# Altium schematic sheet JZ2440_sensors_v1.2.SchDoc
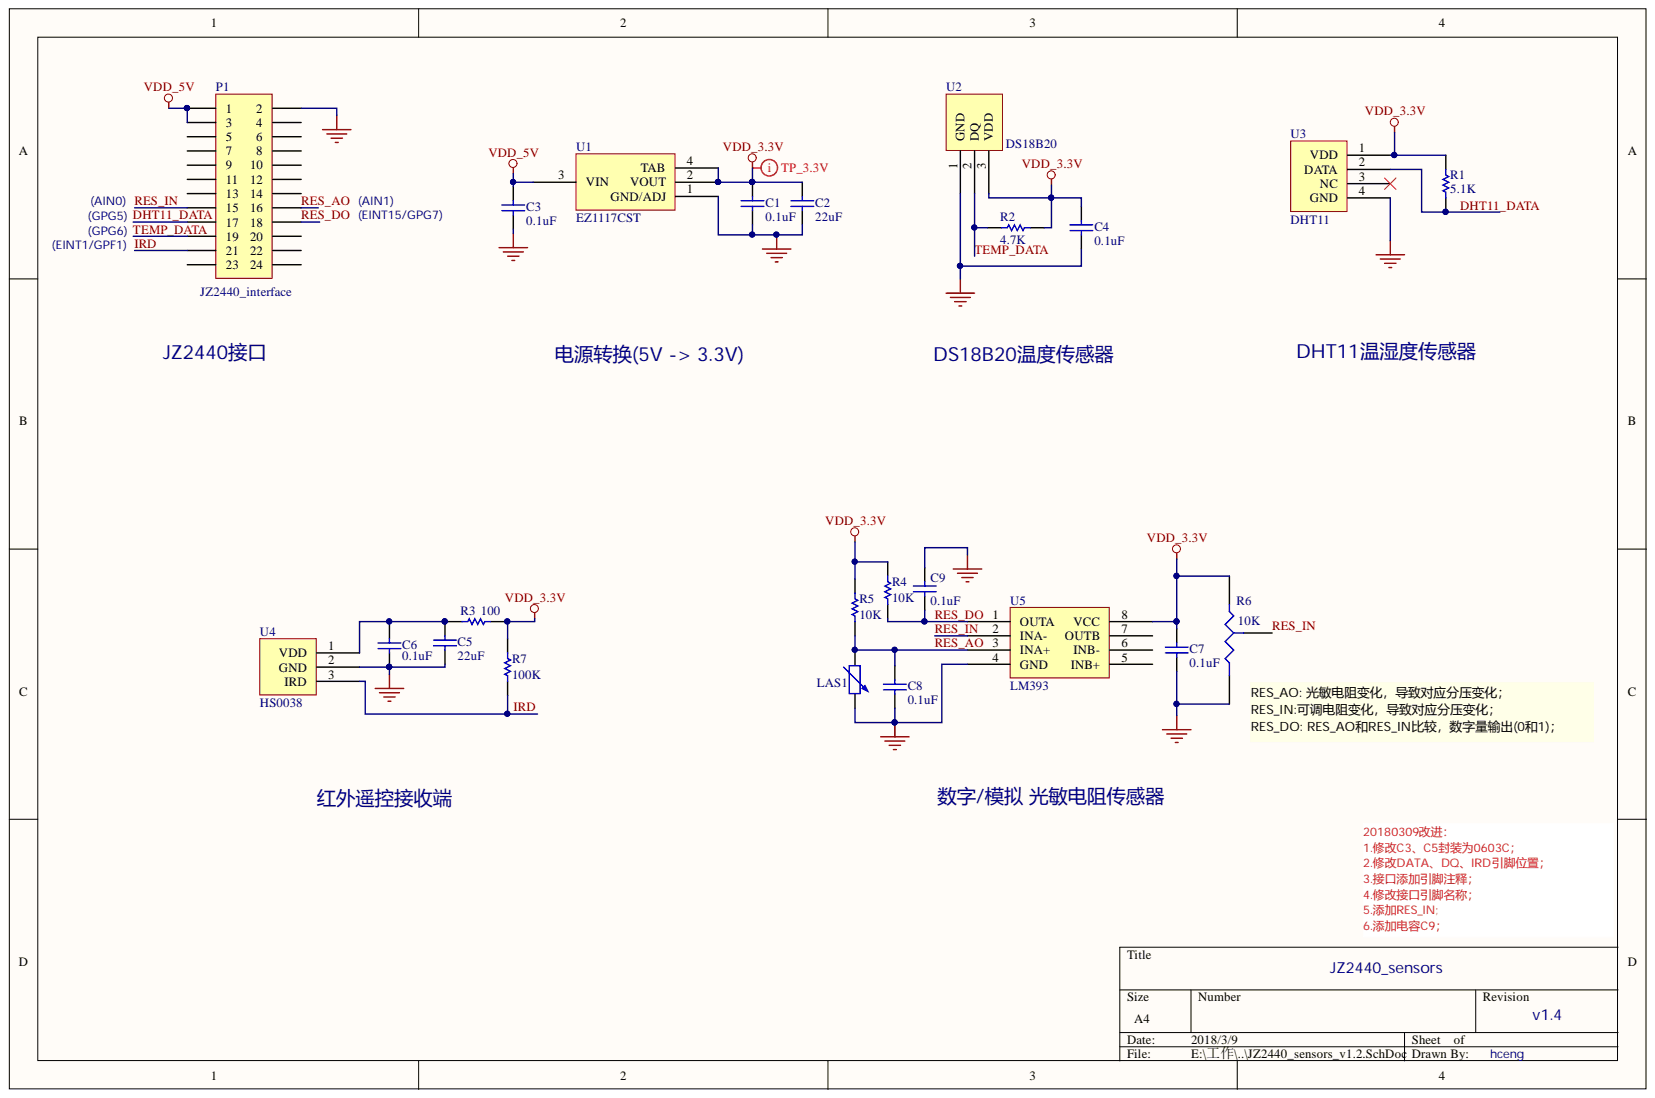
[diagram p0: JZ2440_sensors_v1.2.SchDoc]

## Parts
C1  value 0.1uF  [0603C]  (hidden comment: Cap)
C2  value 22uF  [0603C]  (hidden comment: Cap)
C3  value 0.1uF  [0603C]  (hidden comment: Cap)
C4  value 0.1uF  [0603C]  (hidden comment: Cap)
C5  value 22uF  [0603C]  (hidden comment: Cap)
C6  value 0.1uF  [0603C]  (hidden comment: Cap)
C7  value 0.1uF  [0603C]  (hidden comment: Cap)
C8  value 0.1uF  [0603C]  (hidden comment: Cap)
C?  value 0.1uF  [0603C]  (hidden comment: Cap)
C?  value 0.1uF  [0603C]  (hidden comment: Cap)
LAS1  Res  [HDR1X2]  (value not drawn)
P1  JZ2440_interface  [HDR2X12]
R1  value 5.1K  [0603R]  (hidden comment: Res1)
R2  value 4.7K  [0603R]  (hidden comment: Res1)
R3  value 100  [0603R]  (hidden comment: Res1)
R4  value 10K  [0603R]  (hidden comment: Res1)
R5  value 10K  [0603R]  (hidden comment: Res1)
R6  value 10K  [3362P]  (hidden comment: Res Tap)
R7  value 100K  [0603R]  (hidden comment: Res1)
U1  EZ1117CST  [SOT223]
U2  DS18B20  [T092-3]
U3  DHT11  [HDR1X4]
U4  HS0038  [HDR1X3]
U5  LM393  [SO8-150]

## Nets
GND: C1.1 C2.1 C3.1 C4.1 C5.1 C6.1 C7.1 C8.1 C?.2 LAS1.2 P1.2 R6.2 U1.1(GND/ADJ) U2.1 U3.4(GND) U4.2 U5.4(GND)
VDD_3.3V: C1.2 C2.2 C4.2 C7.2 R1.1 R2.1 R3.1 R4.1 R5.1 R6.1 R7.1 U1.2(VOUT) U1.4(TAB) U2.3 U3.1(VDD) U5.8(VCC)
VDD_5V: C3.2 P1.1 P1.3 U1.3(VIN)
DHT11_DATA: P1.17 R1.2 U3.2(DATA)
IRD: P1.21 R7.2 U4.3
RES_AO: C8.2 LAS1.1 P1.16 R5.2 U5.3(INA+)
RES_DO: C?.1 P1.18 R4.2 U5.1(OUTA)
RES_IN: P1.15 R6.3 U5.2(INA-)
TEMP_DATA: P1.19 R2.2 U2.2
NetC5_2: C5.2 C6.2 R3.2 U4.1

Not connected: P1 4 5 6 7 8 9 10 11 12 13 14 20 22 23 24; U3 3(NC); U5 5(INB+) 6(INB-) 7(OUTB)

## Library data of the parts
C1-C8 C? C? = Cap: Capacitor; Component Kind: Standard; LatestRevisionDate: 17-Jul-2002; LatestRevisionNote: Re-released for DXP Platform.; Library Name: Miscellaneous Devices.IntLib; PackageReference: RAD-0.3; Published: 8-Jun-2000; Signal Integrity: Cap; Simulation: CAP; Publisher: Altium Limited
LAS1 = Res Adj2: Variable Resistor; Set Position: 0.5; Value: 1K; Component Kind: Standard; LatestRevisionDate: 17-Jul-2002; LatestRevisionNote: Re-released for DXP Platform.; Library Name: Miscellaneous Devices.IntLib; PackageDescription: Axial Device, Thru-Hole; 2 Leads; 0.6 in Pin Spacing; PackageReference: AXIAL-0.6; Published: 8-Jun-2000; Signal Integrity: Res Adj; Simulation: VRES; Publisher: Altium Limited
P1 = Header 12X2: Header, 12-Pin, Dual row; Component Kind: Standard; LatestRevisionDate: 17-Jul-2002; LatestRevisionNote: Re-released for DXP Platform.; Library Name: Miscellaneous Connectors.IntLib; Signal Integrity: Connector; Publisher: Altium Limited
R1-R5 R7 = Res1: Resistor; Component Kind: Standard; LatestRevisionDate: 17-Jul-2002; LatestRevisionNote: Re-released for DXP Platform.; Library Name: Miscellaneous Devices.IntLib; PackageReference: AXIAL-0.3; Published: 8-Jun-2000; Signal Integrity: Res; Simulation: RESISTOR; Publisher: Altium Limited
R6 = Res Tap: Tapped Resistor; Set Position: 0.5; Sim Note: Enter the Resistance value and the set point into parameter fields.; Component Kind: Standard; LatestRevisionDate: 17-Jul-2002; LatestRevisionNote: Re-released for DXP Platform.; Library Name: Miscellaneous Devices.IntLib; PackageDescription: Variable Resistor, Thru-Hole; 3 Leads; PackageReference: VR3; Published: 24-Mar-1999; Signal Integrity: Res Tap; Simulation: POT; Publisher: Altium Limited
U1 = EZ1117CST: Component Kind: Standard; Library Name: spi_camera.SCHLIB
U2 = DS18B20: Component Kind: Standard; Library Name: spi_camera.SCHLIB
U3 = DHT11: Component Kind: Standard; Library Name: spi_camera.SCHLIB
U4 = HS0038: Component Kind: Standard; Library Name: spi_camera.SCHLIB
U5 = LM393: Component Kind: Standard; Library Name: spi_camera.SCHLIB
